# Altium schematic sheet 1_CONNECTORS.SchDoc(1_CONNECTORS)
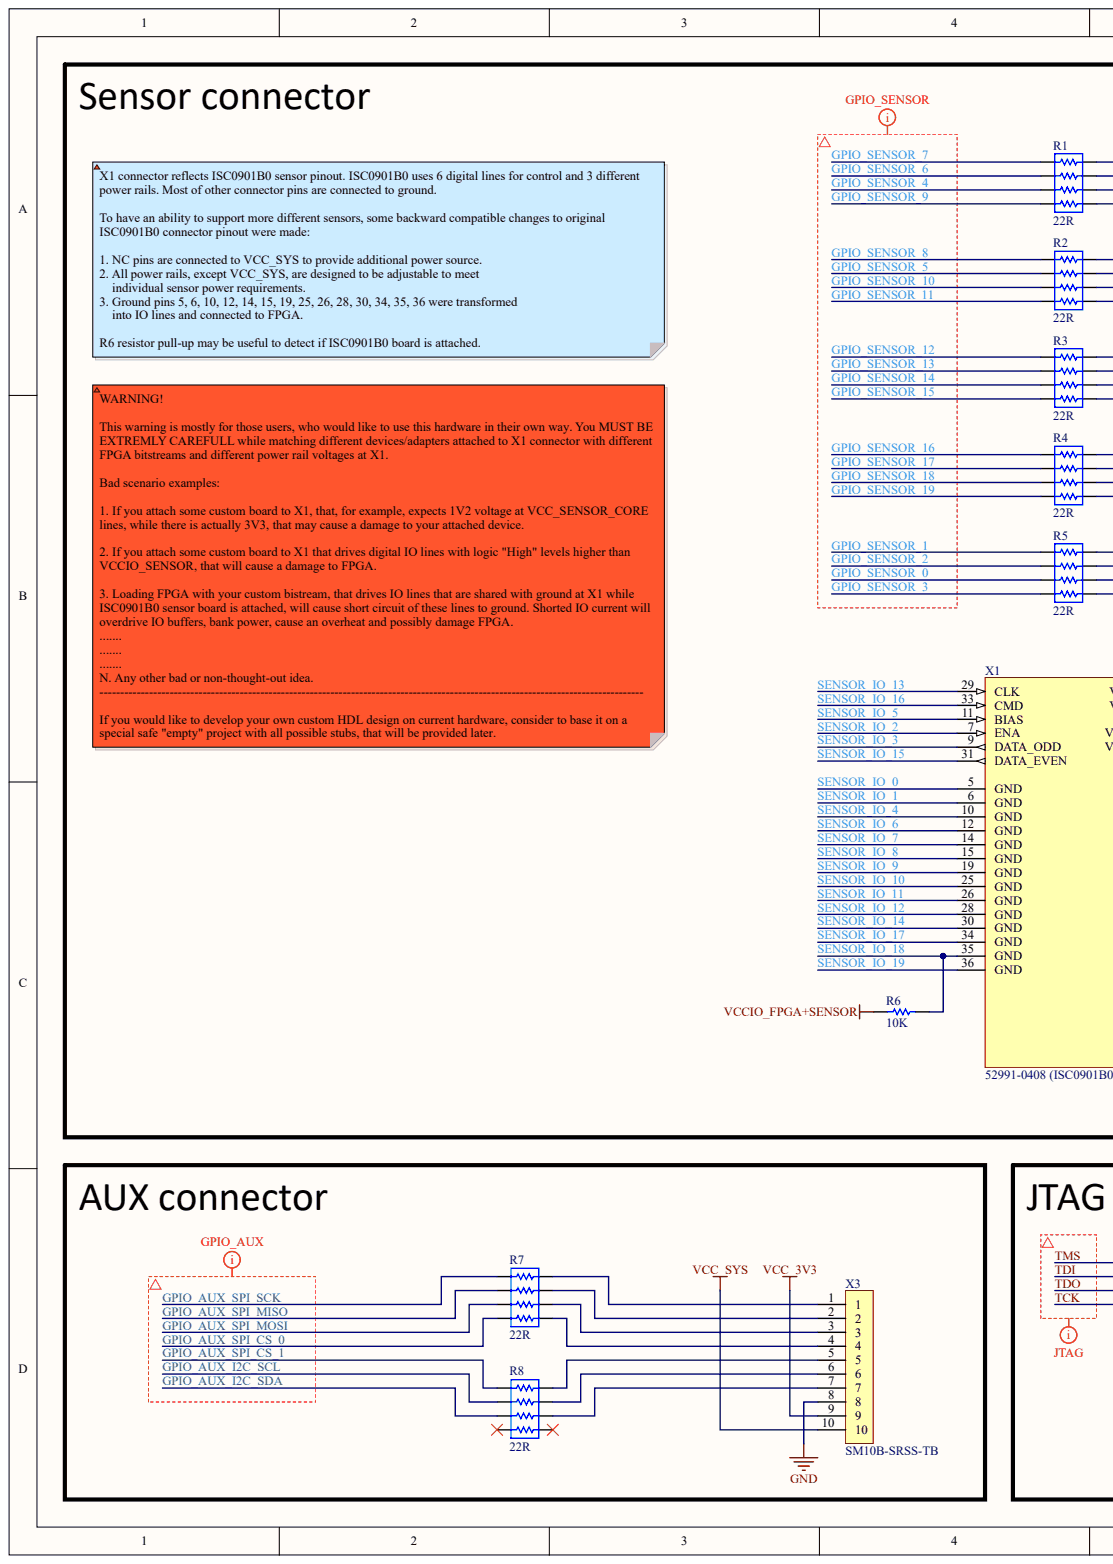
[diagram p0: 1_CONNECTORS.SchDoc, left]
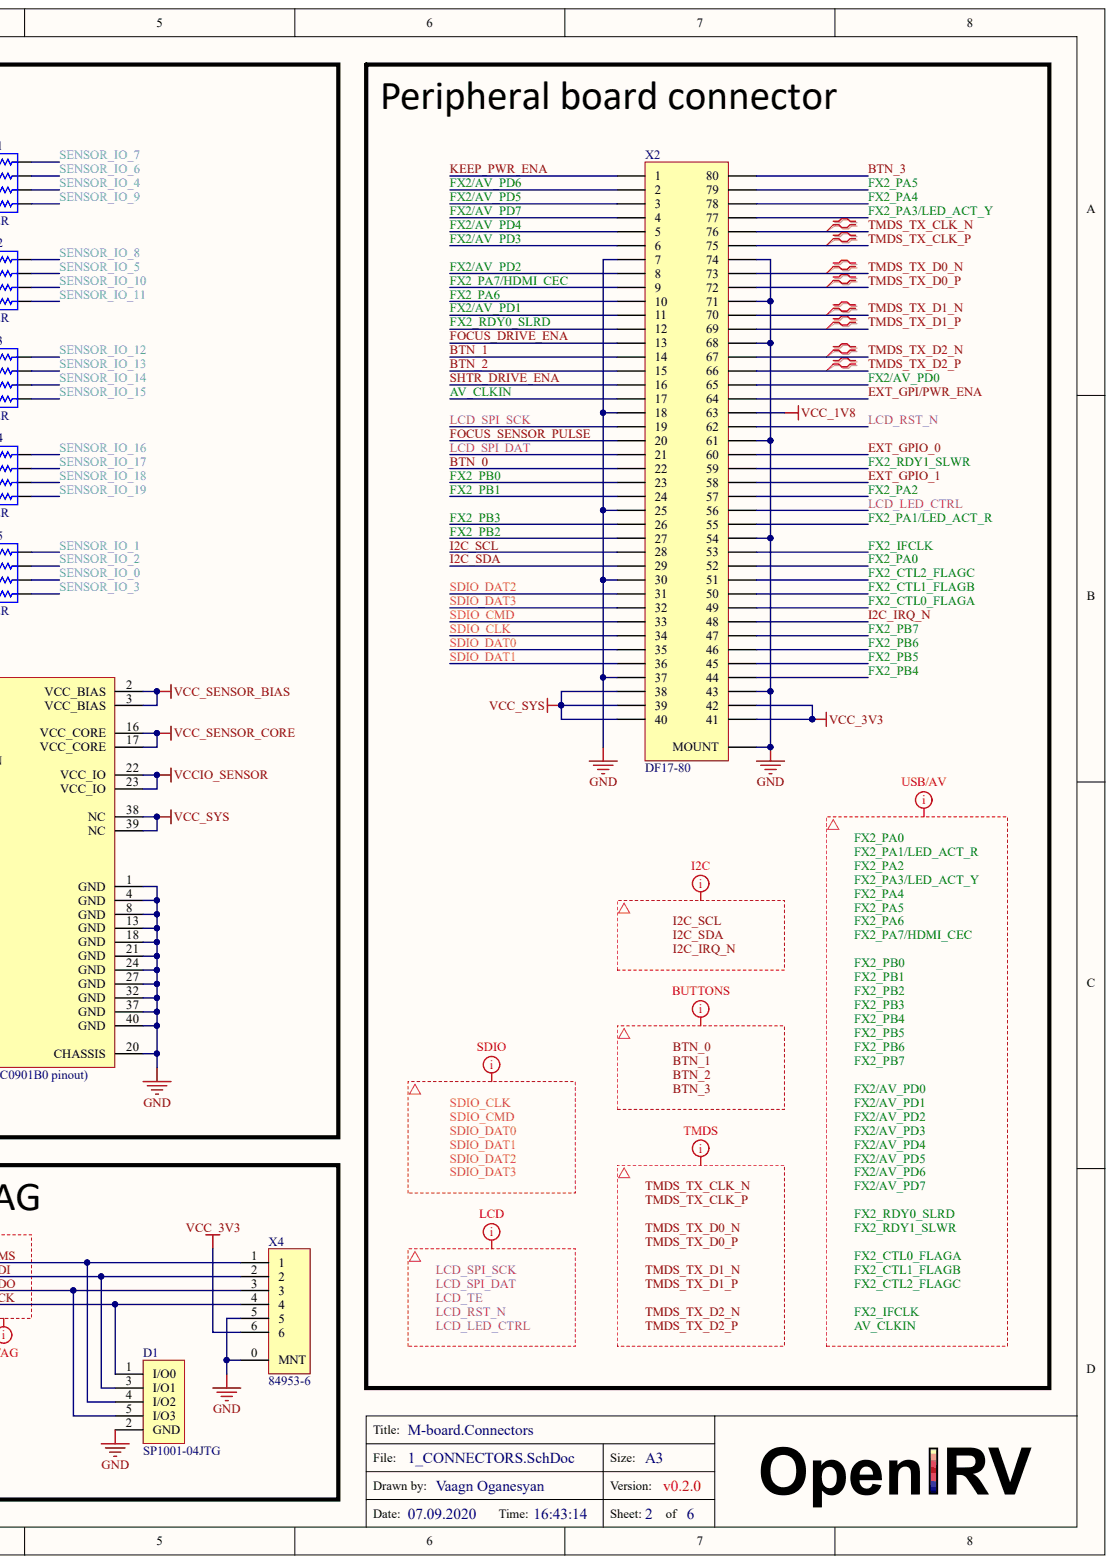
[diagram p1: 1_CONNECTORS.SchDoc, right]

## Parts
D1  SP1001-04JTG  [SP1001-04JTG]
R1  22R  [R0804]
R2  22R  [R0804]
R3  22R  [R0804]
R4  22R  [R0804]
R5  22R  [R0804]
R6  10K  [R0402[1005]]
R7  22R  [R0804]
R8  22R  [R0804]
X1  52991-0408 (ISC0901B0 pinout)  [52991-0408]
X2  DF17-80  [DF17(2.0)-80DP-0.5V(57)]
X3  SM10B-SRSS-TB  [SM10B-SRSS-TB]
X4  84953-6  [84953-6]

## Nets
GND: D1.2(GND) X1.1(GND) X1.4(GND) X1.8(GND) X1.13(GND) X1.18(GND) X1.20(CHASSIS) X1.21(GND) X1.24(GND) X1.27(GND) X1.32(GND) X1.37(GND) X1.40(GND) X2.0(MOUNT) X2.7 X2.18 X2.25 X2.30 X2.37 X2.43 X2.54 X2.61 X2.68 X2.71 X2.74 X3.8 X4.0(MNT) X4.5
VCC_1V8: X2.63
VCC_3V3: X2.41 X2.42 X3.9 X4.6
VCC_SENSOR_BIAS: X1.2(VCC_BIAS) X1.3(VCC_BIAS)
VCC_SENSOR_CORE: X1.16(VCC_CORE) X1.17(VCC_CORE)
VCC_SYS: X1.38(NC) X1.39(NC) X2.38 X2.39 X2.40 X3.10
VCCIO_FPGA+SENSOR: R6.2
VCCIO_SENSOR: X1.22(VCC_IO) X1.23(VCC_IO)
AV_CLKIN: X2.17
BTN_0: X2.22
BTN_1: X2.14
BTN_2: X2.15
BTN_3: X2.80
EXT_GPI/PWR_ENA: X2.64
EXT_GPIO_0: X2.60
EXT_GPIO_1: X2.58
FOCUS_DRIVE_ENA: X2.13
FOCUS_SENSOR_PULSE: X2.20
FX2/AV_PD0: X2.65
FX2/AV_PD1: X2.11
FX2/AV_PD2: X2.8
FX2/AV_PD3: X2.6
FX2/AV_PD4: X2.5
FX2/AV_PD5: X2.3
FX2/AV_PD6: X2.2
FX2/AV_PD7: X2.4
FX2_CTL0_FLAGA: X2.49
FX2_CTL1_FLAGB: X2.50
FX2_CTL2_FLAGC: X2.51
FX2_IFCLK: X2.53
FX2_PA0: X2.52
FX2_PA1/LED_ACT_R: X2.55
FX2_PA2: X2.57
FX2_PA3/LED_ACT_Y: X2.77
FX2_PA4: X2.78
FX2_PA5: X2.79
FX2_PA6: X2.10
FX2_PA7/HDMI_CEC: X2.9
FX2_PB0: X2.23
FX2_PB1: X2.24
FX2_PB2: X2.27
FX2_PB3: X2.26
FX2_PB4: X2.44
FX2_PB5: X2.45
FX2_PB6: X2.46
FX2_PB7: X2.47
FX2_RDY0_SLRD: X2.12
FX2_RDY1_SLWR: X2.59
GPIO_AUX_I2C_SCL: R8.6
GPIO_AUX_I2C_SDA: R8.7
GPIO_AUX_SPI_CS_0: R7.8
GPIO_AUX_SPI_CS_1: R8.5
GPIO_AUX_SPI_MISO: R7.6
GPIO_AUX_SPI_MOSI: R7.7
GPIO_AUX_SPI_SCK: R7.5
GPIO_SENSOR_0: R5.7
GPIO_SENSOR_1: R5.5
GPIO_SENSOR_2: R5.6
GPIO_SENSOR_3: R5.8
GPIO_SENSOR_4: R1.7
GPIO_SENSOR_5: R2.6
GPIO_SENSOR_6: R1.6
GPIO_SENSOR_7: R1.5
GPIO_SENSOR_8: R2.5
GPIO_SENSOR_9: R1.8
GPIO_SENSOR_10: R2.7
GPIO_SENSOR_11: R2.8
GPIO_SENSOR_12: R3.5
GPIO_SENSOR_13: R3.6
GPIO_SENSOR_14: R3.7
GPIO_SENSOR_15: R3.8
GPIO_SENSOR_16: R4.5
GPIO_SENSOR_17: R4.6
GPIO_SENSOR_18: R4.7
GPIO_SENSOR_19: R4.8
I2C_IRQ_N: X2.48
I2C_SCL: X2.28
I2C_SDA: X2.29
KEEP_PWR_ENA: X2.1
LCD_LED_CTRL: X2.56
LCD_RST_N: X2.62
LCD_SPI_DAT: X2.21
LCD_SPI_SCK: X2.19
SDIO_CLK: X2.34
SDIO_CMD: X2.33
SDIO_DAT0: X2.35
SDIO_DAT1: X2.36
SDIO_DAT2: X2.31
SDIO_DAT3: X2.32
SENSOR_IO_0: R5.2 X1.5(GND)
SENSOR_IO_1: R5.4 X1.6(GND)
SENSOR_IO_2: R5.3 X1.7(ENA)
SENSOR_IO_3: R5.1 X1.9(DATA_ODD)
SENSOR_IO_4: R1.2 X1.10(GND)
SENSOR_IO_5: R2.3 X1.11(BIAS)
SENSOR_IO_6: R1.3 X1.12(GND)
SENSOR_IO_7: R1.4 X1.14(GND)
SENSOR_IO_8: R2.4 X1.15(GND)
SENSOR_IO_9: R1.1 X1.19(GND)
SENSOR_IO_10: R2.2 X1.25(GND)
SENSOR_IO_11: R2.1 X1.26(GND)
SENSOR_IO_12: R3.4 X1.28(GND)
SENSOR_IO_13: R3.3 X1.29(CLK)
SENSOR_IO_14: R3.2 X1.30(GND)
SENSOR_IO_15: R3.1 X1.31(DATA_EVEN)
SENSOR_IO_16: R4.4 X1.33(CMD)
SENSOR_IO_17: R4.3 X1.34(GND)
SENSOR_IO_18: R4.2 R6.1 X1.35(GND)
SENSOR_IO_19: R4.1 X1.36(GND)
SHTR_DRIVE_ENA: X2.16
TCK: D1.1(I/O0) X4.4
TDI: D1.3(I/O1) X4.2
TDO: D1.5(I/O3) X4.3
TMDS_TX_CLK_N: X2.76
TMDS_TX_CLK_P: X2.75
TMDS_TX_D0_N: X2.73
TMDS_TX_D0_P: X2.72
TMDS_TX_D1_N: X2.70
TMDS_TX_D1_P: X2.69
TMDS_TX_D2_N: X2.67
TMDS_TX_D2_P: X2.66
TMS: D1.4(I/O2) X4.1
NetR7_1: R7.1 X3.4
NetR7_2: R7.2 X3.3
NetR7_3: R7.3 X3.2
NetR7_4: R7.4 X3.1
NetR8_2: R8.2 X3.7
NetR8_3: R8.3 X3.6
NetR8_4: R8.4 X3.5
LCD_TE:
N00078:
N00079:
N00080:
N00081:
N00082:
N00083:
N00084:
N00085:
N00086:

Not connected: R8 1 8

## Library data of the parts
D1 = SP1001-04JTG: ESD protection diode; Component Kind: Standard; Library Name: general.IntLib
R1-R5 R7 R8 = RES_ARRAY: Power: 0.06W; Tolerance: 5%; Component Kind: Standard; Library Name: general.IntLib; Mounting: SMD; Value: 22R
R6 = RES: Power: 0.06W; Tolerance: 5%; Component Kind: Standard; Library Name: general.IntLib; Mounting: SMD; Value: 10K
X1 = 52991-0408: Molex 40 pin receptacle; Component Kind: Standard; Library Name: general.IntLib
X2 = DF17-80: Component Kind: Standard; Library Name: general.IntLib
X3 = SM10B-SRSS-TB: Component Kind: Standard; Library Name: general.IntLib
X4 = 84953-6: Component Kind: Standard; Library Name: general.IntLib
